# Altium schematic sheet Spannungsmessung.SchDoc
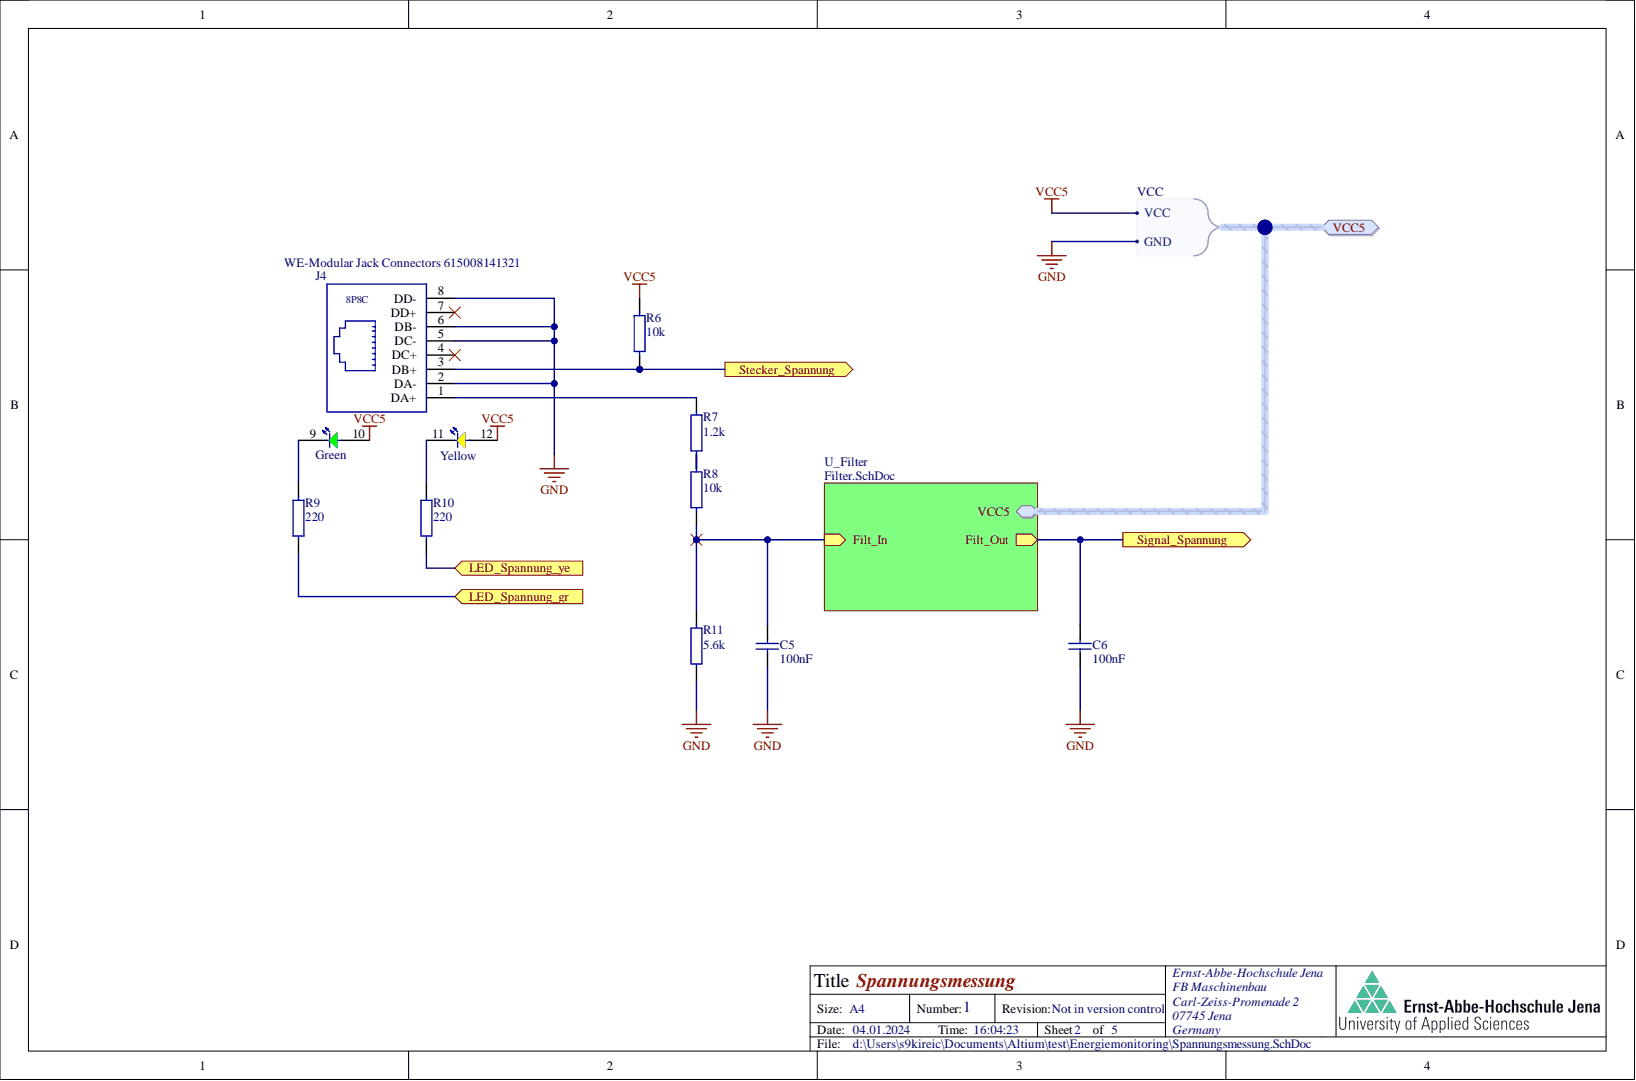
[diagram p0: Spannungsmessung.SchDoc]

## Parts
C5  100nF  [SMD-0805]
C6  100nF  [SMD-0805]
J4  WE-Modular Jack Connectors 615008141321  [615008141321]
R6  10k  [R-SMD-0805]
R7  1.2k  [R-SMD-0805]
R8  10k  [R-SMD-0805]
R9  220  [R-SMD-0805]
R10  220  [R-SMD-0805]
R11  5.6k  [R-SMD-0805]

## Ports
LED_Spannung_gr, LED_Spannung_ye, Signal_Spannung, Stecker_Spannung, VCC5, VCC5.GND, VCC5.VCC

## Nets
GND: C5.1 C6.1 J4.2(DA-) J4.5(DC-) J4.6(DB-) J4.8(DD-) R11.1 ; port VCC5
LED_Spannung_gr: R9.1 ; port LED_Spannung_gr
LED_Spannung_ye: R10.1 ; port LED_Spannung_ye
Signal_Spannung: C6.2 ; port Signal_Spannung
Stecker_Spannung: J4.3(DB+) R6.1 ; port Stecker_Spannung
VCC5: J4.10 J4.12 R6.2 ; port VCC5
NetC14_2: C5.2 R8.1 R11.2
NetJ4_1: J4.1(DA+) R7.2
NetJ4_4: J4.4(DC+)
NetJ4_7: J4.7(DD+)
NetJ4_9: J4.9 R9.2
NetJ4_11: J4.11 R10.2
NetR17_1: R7.1 R8.2

## Library data of the parts
C5 C6 = C: Kondensator; Component Kind: Standard; Library Name: SMD.IntLib; Value: 100nF
J4 = WE-Modular Jack Connectors 615008141321: WR-COM Modular Jack Horizontal Shielded 1x1 TH LED 2x Bipolar Green/Yellow Green=Fw EMI  fingers  8P8C Tab Up; Manufacturer: W¨¹rth Elektronik eiSos GmbH; ComponentLink1 Description: Manufacturer; ComponentLink2 Description: Datasheet; NoPins: 12; Component Kind: Standard; ComponentLink1URL: http://www.we-online.de; ComponentLink2URL: http://katalog.we-online.de/em/datasheet/615008141321.pdf; Created Date: 10/22/2014; Library Name: WE_WR-MJ_Modular Jack Connectors_rev15b.IntLib; partNo: 615008141321; Created by: Karrer Zheng
R6-R11 = R: Widerstand; Component Kind: Standard; Library Name: SMD.IntLib
  R6 R8: Value: 10k
  R7: Value: 1.2k
  R9 R10: Value: 220
  R11: Value: 5.6k
